# Altium schematic sheet Pre_Charge_Interface.SchDoc(Pre_Charge_Interface)
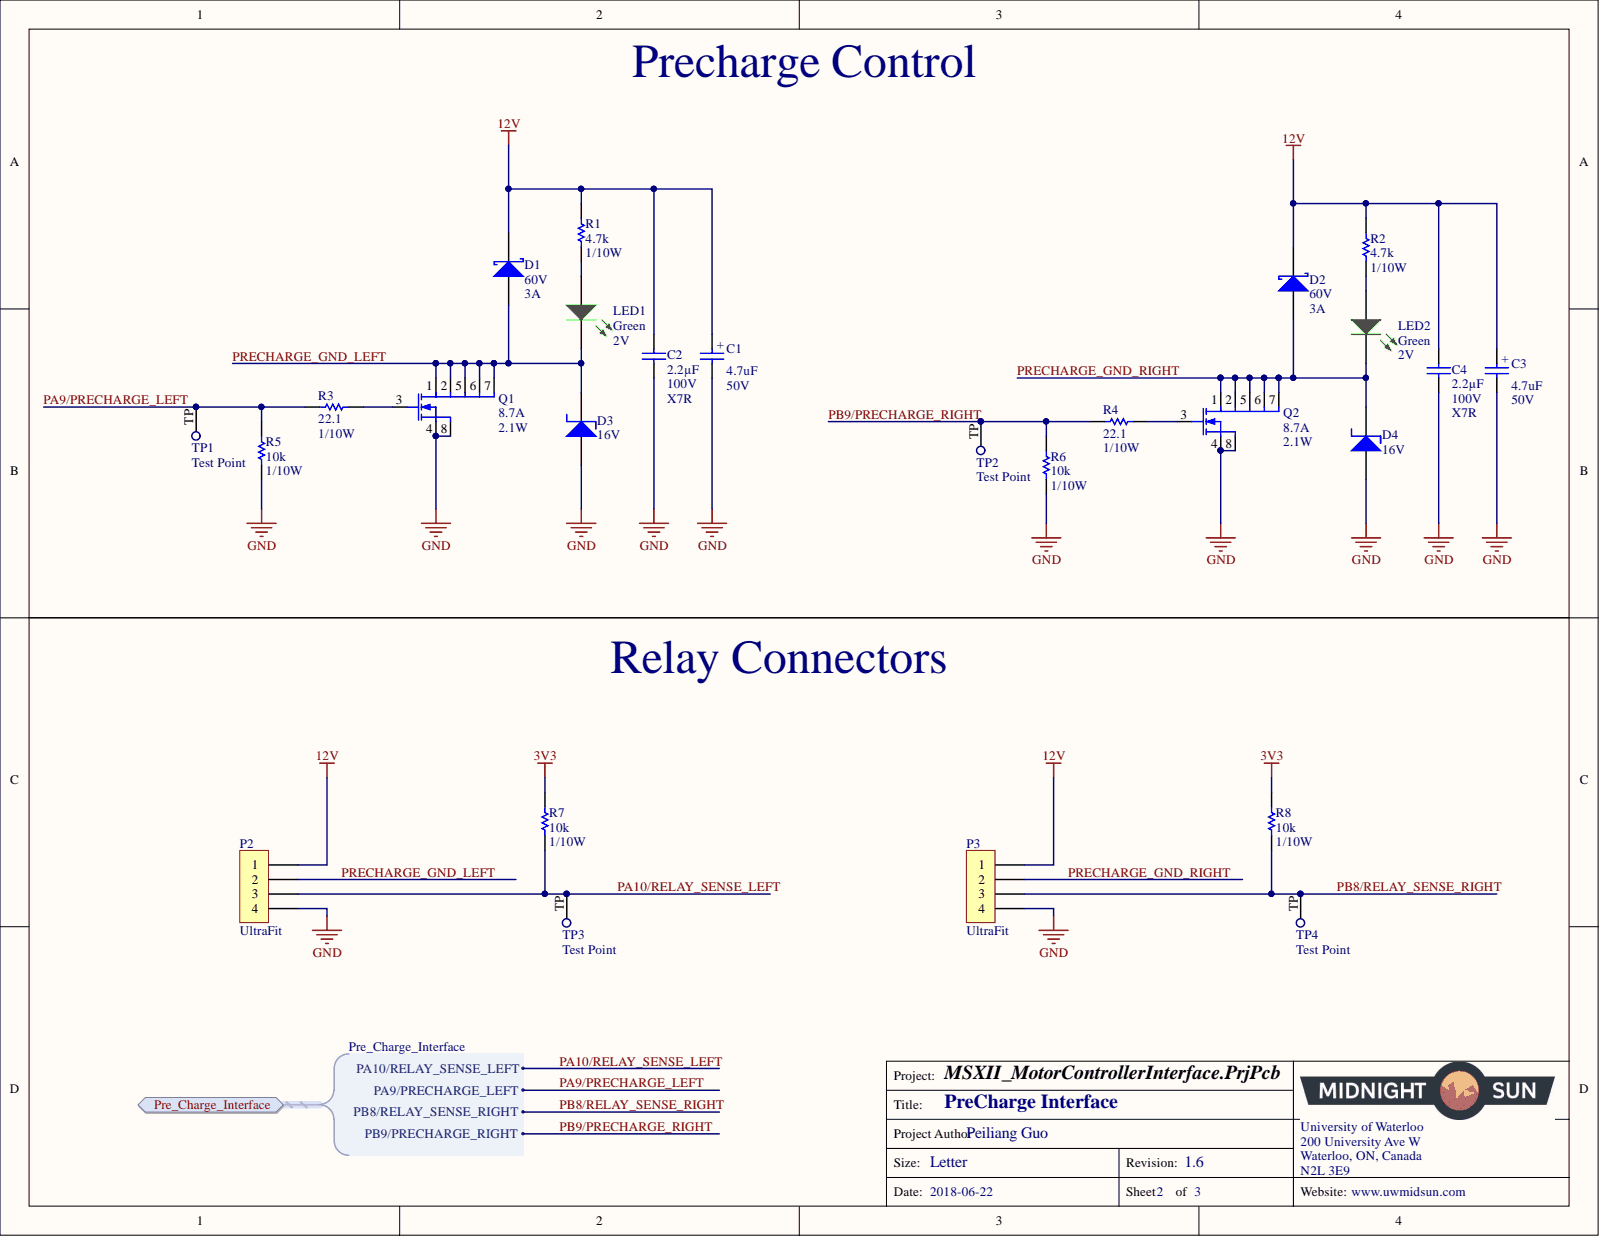
[diagram p0: Pre_Charge_Interface.SchDoc]

## Parts
C1  Voltage Rating (DC): 50V  Capacitance: 4.7uF  [CAP ALUM 6.3MM RADIAL SMD]  (hidden comment: EEE-1HA4R7NP)
C2  Voltage: 100V  Temperature Coefficient: X7R  Capacitance: 2.2µF  [CAP, 1206]  (hidden comment: CAP CER 2.2UF 100V ±20% X7R 1206)
C3  Voltage Rating (DC): 50V  Capacitance: 4.7uF  [CAP ALUM 6.3MM RADIAL SMD]  (hidden comment: EEE-1HA4R7NP)
C4  Voltage: 100V  Temperature Coefficient: X7R  Capacitance: 2.2µF  [CAP, 1206]  (hidden comment: CAP CER 2.2UF 100V ±20% X7R 1206)
D1  Voltage - DC Reverse (Vr) (Max): 60V  Current - Average Rectified (Io): 3A  [Diode, SMA]  (hidden comment: DIODE SCHOTTKY 60V 3A SMA)
D2  Voltage - DC Reverse (Vr) (Max): 60V  Current - Average Rectified (Io): 3A  [Diode, SMA]  (hidden comment: DIODE SCHOTTKY 60V 3A SMA)
D3  Voltage - Zener (Nom): 16V  [DO-214AA Diode]  (hidden comment: DIODE ZENER 16V 5W DO-214AA (SMB))
D4  Voltage - Zener (Nom): 16V  [DO-214AA Diode]  (hidden comment: DIODE ZENER 16V 5W DO-214AA (SMB))
LED1  Voltage - Forward (Vf) (Typ): 2V  Color: Green  [LED_0603]  (hidden comment: LED GREEN CLEAR 2V 0603)
LED2  Voltage - Forward (Vf) (Typ): 2V  Color: Green  [LED_0603]  (hidden comment: LED GREEN CLEAR 2V 0603)
P2  Series: UltraFit  [CONN, 4POS ULTRA-FIT]  (hidden comment: CONN 4POS ULTRA-FIT 0.138")
P3  Series: UltraFit  [CONN, 4POS ULTRA-FIT]  (hidden comment: CONN 4POS ULTRA-FIT 0.138")
Q1  Power Dissipation (Max): 2.1W  Current - Continuous Drain (Id) @ 25°C: 8.7A  [MOSFET N-CH 30V 12A 2mmx2mm PQFN]  (hidden comment: MOSFET N-CH 30V 8.7A 2.1W 6-PQFN (2x2))
Q2  Power Dissipation (Max): 2.1W  Current - Continuous Drain (Id) @ 25°C: 8.7A  [MOSFET N-CH 30V 12A 2mmx2mm PQFN]  (hidden comment: MOSFET N-CH 30V 8.7A 2.1W 6-PQFN (2x2))
R1  Resistance (Ohms): 4.7k  Power (Watts): 1/10W  [RES, 0603]  (hidden comment: RES 4.7K OHM 1% 1/10W 0603)
R2  Resistance (Ohms): 4.7k  Power (Watts): 1/10W  [RES, 0603]  (hidden comment: RES 4.7K OHM 1% 1/10W 0603)
R3  Resistance: 22.1  Power: 1/10W  [RES, 0603]  (hidden comment: RES 22.1 OHM 1% 1/10W 0603)
R4  Resistance: 22.1  Power: 1/10W  [RES, 0603]  (hidden comment: RES 22.1 OHM 1% 1/10W 0603)
R5  Resistance: 10k  Power: 1/10W  [RES, 0603]  (hidden comment: RES 10K OHM 1% 1/10W 0603)
R6  Resistance: 10k  Power: 1/10W  [RES, 0603]  (hidden comment: RES 10K OHM 1% 1/10W 0603)
R7  Resistance: 10k  Power: 1/10W  [RES, 0603]  (hidden comment: RES 10K OHM 1% 1/10W 0603)
R8  Resistance: 10k  Power: 1/10W  [RES, 0603]  (hidden comment: RES 10K OHM 1% 1/10W 0603)
TP1  Test Point  [Test Point]  (no BOM)
TP2  Test Point  [Test Point]  (no BOM)
TP3  Test Point  [Test Point]  (no BOM)
TP4  Test Point  [Test Point]  (no BOM)

## Ports
Pre_Charge_Interface, Pre_Charge_Interface.PA9/PRECHARGE_LEFT, Pre_Charge_Interface.PA10/RELAY_SENSE_LEFT, Pre_Charge_Interface.PB8/RELAY_SENSE_RIGHT, Pre_Charge_Interface.PB9/PRECHARGE_RIGHT

## Nets
3V3: R7.1 R8.1
12V: C1.1 C2.1 C3.1 C4.1 D1.2 D2.2 P2.1 P3.1 R1.1 R2.1
GND: C1.2 C2.2 C3.2 C4.2 D3.1 D4.1 P2.4 P3.4 Q1.4 Q1.8 Q2.4 Q2.8 R5.2 R6.2
PA9/PRECHARGE_LEFT: R3.1 R5.1 TP1.TP ; port Pre_Charge_Interface
PA10/RELAY_SENSE_LEFT: P2.3 R7.2 TP3.TP ; port Pre_Charge_Interface
PB8/RELAY_SENSE_RIGHT: P3.3 R8.2 TP4.TP ; port Pre_Charge_Interface
PB9/PRECHARGE_RIGHT: R4.1 R6.1 TP2.TP ; port Pre_Charge_Interface
PRECHARGE_GND_LEFT: D1.1 D3.2 LED1.2 P2.2 Q1.1 Q1.2 Q1.5 Q1.6 Q1.7
PRECHARGE_GND_RIGHT: D2.1 D4.2 LED2.2 P3.2 Q2.1 Q2.2 Q2.5 Q2.6 Q2.7
NetLED1_1: LED1.1 R1.2
NetLED2_1: LED2.1 R2.2
NetQ1_3: Q1.3 R3.2
NetQ2_3: Q2.3 R4.2

## Library data of the parts
C1 C3 = CAP ALUM 4.7UF 20% 50V SMD: 4.7µF 50V Aluminum Electrolytic Capacitors Radial, Can - SMD 2000 Hrs @ 85°C; Manufacturer 1: Panasonic; Tolerance: 20%; Ripple Current (AC): 31mA; Life (Hours): 2000Hour; Description 1: CAPACITOR ALUM ELEC, 4.7UF, 50V, 20%, SMD; Width: 650um; Voltage Rating: 50V; Termination: SMD/SMT; Supplier Part Number 1: PCE4304CT-ND; Supplier 1: Digi-Key; Stock 1: 19771; Ripple Current: 31mA; REACH SVHC: No SVHC; Radiation Hardening: No; Pricing 1: 1=0.43, 10=0.302, 100=0.1986, 500=0.1471 (USD); Packaging: Tape and Reel; Package Quantity: 1; Mount: Surface Mount,PCB; Min Operating Temperature: -40°C; Max Operating Temperature: 85°C; Manufacturer Part Number 1: EEE-1HA4R7NP; Length: 5.5mm; Lead Free: Lead Free; Height - Seated (Max): 0.213inch; Height: 5.4mm; Diameter: 6.3mm; ComponentLink6URL: https://datasheet.ciiva.com/14606/70255710-14606079.pdf; ComponentLink6Description: https://datasheet.ciiva.com/14606/70255710-14606079.pdf; ComponentLink5URL: https://datasheet.ciiva.com/31414/aba0000ce2-31414684.pdf; ComponentLink5Description: https://datasheet.ciiva.com/31414/aba0000ce2-31414684.pdf; ComponentLink4URL: https://datasheet.ciiva.com/24027/aba0000ce2-40801-24027083.pdf; ComponentLink4Description: https://datasheet.ciiva.com/24027/aba0000ce2-40801-24027083.pdf; ComponentLink3URL: https://datasheet.ciiva.com/17536/aba0000ce2-17536647.pdf; ComponentLink3Description: https://datasheet.ciiva.com/17536/aba0000ce2-17536647.pdf; ComponentLink2URL: https://datasheet.ciiva.com/14600/70255710-14600343.pdf; ComponentLink2Description: https://datasheet.ciiva.com/14600/70255710-14600343.pdf; ComponentLink1URL: https://datasheet.ciiva.com/46374/aba0000ce2-46374373.pdf; ComponentLink1Description: https://datasheet.ciiva.com/46374/aba0000ce2-46374373.pdf; Component Kind: Standard; Case/Package: Radial; Library Name: Schematic Diagrams.SchLib
C2 C4 = CAP CER 2.2UF 100V ±20% X7R 1206: 2.2µF ±20% 100V Ceramic Capacitor X7R 1206 (3216 Metric); Manufacturer Part Number 1: GRM31CR72A225MA73L; Manufacturer 1: Murata Electronics North America; Tolerance: ±20%; Thickness (Max): 0.071" (1.80mm); Supplier Part Number 1: 490-12773-1-ND; Supplier 1: Digi-Key; Size / Dimension: 0.126" L x 0.063" W (3.20mm x 1.60mm); Ratings: -; Part Status: Active; Packaging: Cut Tape (CT); Package / Case: 1206 (3216 Metric); Operating Temperature: -55°C ~ 125°C; Mounting Type: Surface Mount, MLCC; Lead Style: -; Lead Spacing: -; Height - Seated (Max): -; ComponentLink1URL: http://www.murata.com/~/media/webrenewal/support/library/catalog/products/capacitor/mlcc/c02e.ashx; ComponentLink1Description: http://www.murata.com/~/media/webrenewal/support/library/catalog/products/capacitor/mlcc/c02e.ashx; Component Kind: Standard; Library Name: Schematic Diagrams.SchLib
D1 D2 = DIODE SCHOTTKY 60V 3A SMA: Diode Schottky 60V 3A Surface Mount SMA; Manufacturer Part Number 1: B360A-13-F; Manufacturer 1: Diodes Incorporated; Voltage - Forward (Vf) (Max) @ If: 700mV @ 3A; Speed: Fast Recovery =< 500ns, > 200mA (Io); Current - Reverse Leakage @ Vr: 500µA @ 60V; Capacitance @ Vr, F: 200pF @ 4V, 1MHz; Supplier Part Number 1: B360A-FDICT-ND; Supplier Device Package: SMA; Supplier 1: Digi-Key; Part Status: Active; Packaging: Cut Tape (CT); Package / Case: DO-214AC, SMA; Operating Temperature - Junction: -55°C ~ 150°C; Mounting Type: Surface Mount; Diode Type: Schottky; ComponentLink1URL: https://www.diodes.com/assets/Datasheets/ds30891.pdf; ComponentLink1Description: https://www.diodes.com/assets/Datasheets/ds30891.pdf; Component Kind: Standard; Library Name: Schematic Diagrams.SchLib
D3 D4 = DIODE ZENER 16V 5W DO-214AA (SMB): Zener Diode 16V 5W ±5% Surface Mount DO-214AA (SMB); Manufacture Part Number: SMBJ5353B-TP; Manufacture: Micro Commercial Co; Voltage - Forward: 1.2V @ 1A; Impedence (Max): 2.5 Ohm; Supplier Part Number 1: SMBJ5353B-TPMSCT-ND; Supplier 1: Digi-Key; Power (Max): 5W; Component Kind: Standard; Library Name: Schematic Diagrams.SchLib
LED1 LED2 = LED GREEN CLEAR 2V 0603: Green 572nm LED Indication - Discrete 2V 0603 (1608 Metric); Manufacturer Part Number: 150060VS75000; Manufacturer: Wurth Electronics Inc.; Wavelength - Dominant: 570nm; Viewing Angle: 140°; Millicandela Rating: 40mcd; Lens Style/Size: Rectangle with Flat Top, 1.10mm x 0.70mm; Lens Color: Colorless; Current - Test: 20mA; Wavelength - Peak: 572nm; Supplier Part Number 1: 732-4980-1-ND; Supplier Device Package: 0603; Supplier 1: Digi-Key; Stock 1: 9408; Size / Dimension: 1.60mm L x 0.80mm W; RoHS: RoHS Compliant; Pricing 1: 1=0.21, 100=0.1617, 500=0.13964 (CAD); Part Status: Active; Packaging: Cut Tape (CT); Package / Case: 0603 (1608 Metric); Mounting Type: Surface Mount; Lens Transparency: Clear; Height (Max): 0.80mm; Features: -; ComponentLink1URL: http://katalog.we-online.de/led/datasheet/150060VS75000.pdf; ComponentLink1Description: http://katalog.we-online.de/led/datasheet/150060VS75000.pdf; Component Kind: Standard; Category: Optoelectronics; Library Name: Schematic Diagrams.SchLib
P2 P3 = CONN 4POS ULTRA-FIT 0.138": 4 Positions Header Connector 0.138" (3.50mm) Through Hole Tin; Manufacturer Part Number 1: 1722871104; Manufacturer 1: Molex, LLC; Voltage: 400V; Style: Board to Cable/Wire; Shrouding: Shrouded - 4 Wall; Number of Positions Loaded: All; Number of Positions: 4; Material Flammability Rating: UL94 V-0; Mated Stacking Heights: 26.99mm; Contact Length - Post: 0.126" (3.20mm); Termination: Solder; Supplier Part Number 1: WM11703-ND; Supplier 1: Digi-Key; Row Spacing - Mating: -; Pitch - Mating: 0.138" (3.50mm); Part Status: Active; Packaging: Tray; Overall Contact Length: -; Operating Temperature: -40°C ~ 105°C; Number of Rows: 1; Mounting Type: Through Hole; Insulation Material: Liquid Crystal Polymer (LCP); Insulation Height: 0.465" (11.80mm); Insulation Color: Black; Ingress Protection: -; Fastening Type: Latch Holder; Current Rating: -; Contact Type: Male Pin; Contact Shape: Square; Contact Material: Copper Alloy; Contact Length - Mating: -; Contact Finish Thickness - Post: 60µin (1.52µm); Contact Finish Thickness - Mating: 60µin (1.52µm); Contact Finish - Post: Tin; Contact Finish - Mating: Tin; Connector Type: Header; ComponentLink1URL: http://www.molex.com/pdm_docs/sd/1722871104_sd.pdf; ComponentLink1Description: http://www.molex.com/pdm_docs/sd/1722871104_sd.pdf; Component Kind: Standard; Library Name: Schematic Diagrams.SchLib
Q1 Q2 = MOSFET N-CH 30V 8.7A 2.1W 6-PQFN (2x2): Manufacturer Part Number 1: IRLHS6342TRPBF; Manufacturer 1: Infineon Technologies; Vgs(th) (Max) @ Id: 1.1V @ 10µA; Vgs (Max): ±12V; Rds On (Max) @ Id, Vgs: 15.5 mOhm @ 8.5A, 4.5V; Input Capacitance (Ciss) (Max) @ Vds: 1019pF @ 25V; Gate Charge (Qg) (Max) @ Vgs: 11nC @ 4.5V; FET Type: N-Channel; Drive Voltage (Max Rds On,  Min Rds On): 2.5V, 4.5V; Description 1: MOSFET N-CH 30V 8.7A 2X2 PQFN; Technology: MOSFET (Metal Oxide); Supplier Part Number 1: IRLHS6342TRPBFCT-ND; Supplier Device Package: 6-PQFN (2x2); Supplier 1: Digi-Key; Stock 1: 9984; RoHS: RoHS Compliant; Pricing 1: 1=0.79, 10=0.685, 100=0.5118, 500=0.4022, 1000=0.31079 (CAD); Part Status: Active; Packaging: Cut Tape (CT); Package / Case: 6-PowerVDFN; Operating Temperature: -55°C ~ 150°C (TJ); Mounting Type: Surface Mount; FET Feature: -; Drain to Source Voltage (Vdss): 30V; ComponentLink1URL: http://www.infineon.com/dgdl/irlhs6342pbf.pdf?fileId=5546d462533600a401535663b52625ad; ComponentLink1Description: http://www.infineon.com/dgdl/irlhs6342pbf.pdf?fileId=5546d462533600a401535663b52625ad; Component Kind: Standard; Category: Discrete Semiconductor Products; Library Name: Schematic Diagrams.SchLib
R1 R2 = RES 4.7K OHM 1% 1/10W 0603: 4.7k Ohm ±1% 0.1W, 1/10W Chip Resistor 0603 (1608 Metric) Moisture Resistant Thick Film; Manufacturer Part Number 1: RC0603FR-074K7L; Manufacturer 1: Yageo; Temperature Coefficient: ±100ppm/°C; Description 1: RES SMD 4.7K OHM 1% 1/10W 0603; Tolerance: ±1%; Supplier Part Number 1: 311-4.70KHRCT-ND; Supplier Device Package: 0603; Supplier 1: Digi-Key; Stock 1: 3487566; Size / Dimension: 0.063" L x 0.031" W (1.60mm x 0.80mm); RoHS: RoHS Compliant; Pricing 1: 1=0.16, 10=0.022, 100=0.0089, 1000=0.00402, 2500=0.00349 (CAD); Part Status: Active; Packaging: Cut Tape (CT); Package / Case: 0603 (1608 Metric); Operating Temperature: -55°C ~ 155°C; Height - Seated (Max): 0.022" (0.55mm); Features: Moisture Resistant; Failure Rate: -; Composition: Thick Film; ComponentLink1URL: http://www.yageo.com/documents/recent/PYu-RC_Group_51_RoHS_L_7.pdf; ComponentLink1Description: http://www.yageo.com/documents/recent/PYu-RC_Group_51_RoHS_L_7.pdf; Component Kind: Standard; Category: Resistors; Library Name: Schematic Diagrams.SchLib
R3 R4 = RES 22.1 OHM 1% 1/10W 0603: 22.1 Ohm ±1% 0.1W, 1/10W Chip Resistor 0603 (1608 Metric) Moisture Resistant Thick Film; Manufacturer Part Number 1: RC0603FR-0722R1L; Manufacturer 1: Yageo; Temperature Coefficient: ±100ppm/°C; Tolerance: ±1%; Supplier Part Number 1: 311-22.1HRCT-ND; Supplier Device Package: 0603; Supplier 1: Digi-Key; Size / Dimension: 0.063" L x 0.031" W (1.60mm x 0.80mm); Part Status: Active; Packaging: Cut Tape (CT); Package / Case: 0603 (1608 Metric); Operating Temperature: -55°C ~ 155°C; Height - Seated (Max): 0.022" (0.55mm); Failure Rate: -; Composition: Thick Film; ComponentLink1URL: http://www.yageo.com/documents/recent/PYu-RC_Group_51_RoHS_L_7.pdf; ComponentLink1Description: http://www.yageo.com/documents/recent/PYu-RC_Group_51_RoHS_L_7.pdf; Component Kind: Standard; Library Name: Schematic Diagrams.SchLib
R5-R8 = RES 10K OHM 1% 1/10W 0603: RES SMD 10K OHM 1% 1/10W 0603; Manufacturer Part Number 1: RC0603FR-0710KL; Manufacturer 1: Yageo; Temperature Coefficient: ±100ppm/°C; Tolerance: ±1%; Supplier Part Number 1: 311-10.0KHRCT-ND; Supplier Device Package: 0603; Supplier 1: Digi-Key; Size / Dimension: 0.063" L x 0.031" W (1.60mm x 0.80mm); Part Status: Active; Packaging: Cut Tape (CT); Package / Case: 0603 (1608 Metric); Operating Temperature: -55°C ~ 155°C; Height - Seated (Max): 0.022" (0.55mm); Failure Rate: -; Composition: Thick Film; ComponentLink1URL: http://www.yageo.com/documents/recent/PYu-RC_Group_51_RoHS_L_7.pdf; ComponentLink1Description: http://www.yageo.com/documents/recent/PYu-RC_Group_51_RoHS_L_7.pdf; Component Kind: Standard; Library Name: Schematic Diagrams.SchLib
TP1-TP4 = Test Point: Library Name: Schematic Diagrams.SchLib
